# Altium schematic sheet USB_Power.SchDoc
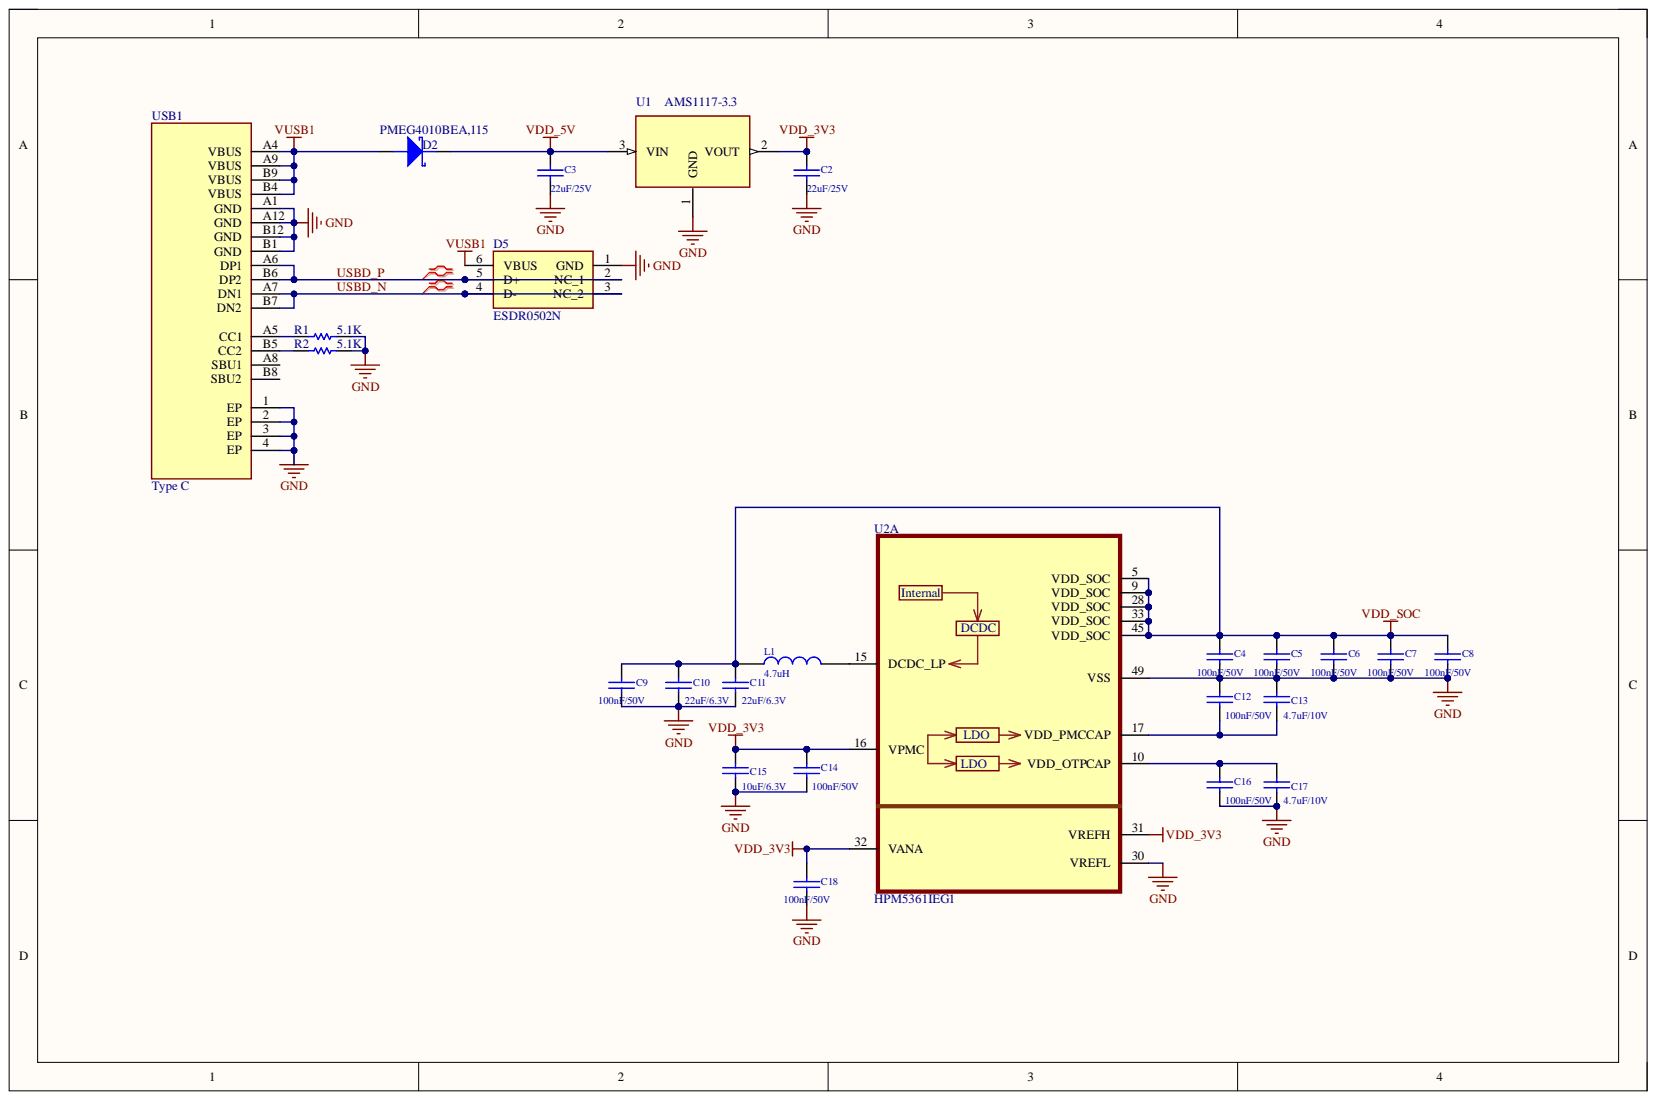
[diagram p0: USB_Power.SchDoc]

## Parts
C2  22uF/25V  [C0805]
C3  22uF/25V  [C0805]
C4  100nF/50V  [C0402]
C5  100nF/50V  [C0402]
C6  100nF/50V  [C0402]
C7  100nF/50V  [C0402]
C8  100nF/50V  [C0402]
C9  100nF/50V  [C0402]
C10  22uF/6.3V  [C0402]
C11  22uF/6.3V  [C0402]
C12  100nF/50V  [C0402]
C13  4.7uF/10V  [C0402]
C14  100nF/50V  [C0402]
C15  10uF/6.3V  [C0402]
C16  100nF/50V  [C0402]
C17  4.7uF/10V  [C0402]
C18  100nF/50V  [C0402]
D2  PMEG4010BEA,115  [SOD-323]
D5  ESDR0502N  [UDFN-6(1x1.2)]
L1  4.7uH  [R0805]
R1  5.1K  [R0402]
R2  5.1K  [R0402]
U1  AMS1117-3.3  [SOT-89]
U2  HPM5361IEG1  [QFN48 6*6]  (part A)
USB1  Type C  [TYPE-C-16]

## Nets
GND: C2.2 C3.2 C4.2 C5.2 C6.2 C7.2 C8.2 C9.2 C10.2 C11.2 C12.1 C13.1 C14.2 C15.2 C16.2 C17.2 C18.2 D5.1(GND) R1.1 R2.1 U1.1 U2.30(VREFL) U2.49(VSS) USB1.1(EP) USB1.2(EP) USB1.3(EP) USB1.4(EP) USB1.A1(GND) USB1.A12(GND) USB1.B1(GND) USB1.B12(GND)
VDD_3V3: C2.1 C14.1 C15.1 C18.1 U1.2 U2.16(VPMC) U2.31(VREFH) U2.32(VANA)
VDD_5V: C3.1 D2.K U1.3
VDD_SOC: C4.1 C5.1 C6.1 C7.1 C8.1 C9.1 C10.1 C11.1 L1.1 U2.5(VDD_SOC) U2.9(VDD_SOC) U2.28(VDD_SOC) U2.33(VDD_SOC) U2.45(VDD_SOC)
VUSB1: D2.A D5.6(VBUS) USB1.A4(VBUS) USB1.A9(VBUS) USB1.B4(VBUS) USB1.B9(VBUS)
USBD_N: D5.3(NC_2) D5.4(D-) USB1.A7(DN1) USB1.B7(DN2)
USBD_P: D5.2(NC_1) D5.5(D+) USB1.A6(DP1) USB1.B6(DP2)
NetC12_2: C12.2 C13.2 U2.17(VDD_PMCCAP)
NetC16_1: C16.1 C17.1 U2.10(VDD_OTPCAP)
NetL1_2: L1.2 U2.15(DCDC_LP)
NetR1_2: R1.2 USB1.A5(CC1)
NetR2_2: R2.2 USB1.B5(CC2)

Not connected: USB1 A8(SBU1) B8(SBU2)

## Library data of the parts
C2 C3 = Cap: Capacitor; Manufacturer: SAMSUNG; JCL-Code: C45783; Name: Capacitance; PreferredModel: CL21A226MAQNNNE; WeldingType: SMD; Component Kind: Standard; Library Name: My_Integrated_Library.SchLib
C4-C9 C12 C14 C16 C18 = Cap: Capacitor; Manufacturer: SAMSUNG(三星); JCL-Code: C307331; Name: Capacitance; PreferredModel: CL05B104KB54PNC; WeldingType: SMD; Component Kind: Standard; Library Name: My_Integrated_Library.SchLib
C10 C11 = Cap: Capacitor; Manufacturer: Murata; JCL-Code: C415703; Name: Capacitance; PreferredModel: GRM155R60J226ME11D; WeldingType: SMD; Component Kind: Standard; Library Name: My_Integrated_Library.SchLib
C13 C17 = Cap: Capacitor; Manufacturer: SAMSUNG; JCL-Code: C368809; Name: Capacitance; PreferredModel: CL05A475KP5NRNC; WeldingType: SMD; Component Kind: Standard; Library Name: My_Integrated_Library.SchLib
C15 = Cap: Capacitor; Manufacturer: SAMSUNG; JCL-Code: C15525; Name: Capacitance; PreferredModel: CL05A106MQ5NUNC; WeldingType: SMD; Component Kind: Standard; Library Name: My_Integrated_Library.SchLib
D2 = Schottky Diode: Manufacturer: Nexperia; JCL-Code: C36975; WeldingType: SMD; Component Kind: Standard; Description: Schottky Diode; Library Name: My_Integrated_Library.SchLib; Name: Schottky Diode; PreferredModel: PMEG4010BEA,115
D5 = ESDR0502N: Ultra Low Capacitance ESD Protection Array; Manufacturer: onsemi(安森美); JCL-Code: C94372; Name: TVS Diode Array; PreferredModel: ESDR0502NMUTAG; WeldingType: SMD; Component Kind: Standard; Library Name: My_Integrated_Library.SchLib
L1 = Inductors: Manufacturer: muRata; JCL-Code: C397305; PreferredModel: LQM21PN4R7NGRD; WeldingType: SMD; Component Kind: Standard; Description: Inductors; Library Name: My_Integrated_Library.SchLib; Name: Inductors
R1 R2 = Res: Resistance; Manufacturer: UNI-ROYAL(厚声); JCL-Code: C25905; PreferredModel: 0402WGF5101TCE; WeldingType: SMD; Component Kind: Standard; Library Name: My_Integrated_Library.SchLib; Name: Resistance
U1 = AMS1117-3.3 SOT-89: LDP; Manufacturer: AMS; JCL-Code: C347256; Name: LDO; WeldingType: SMD; Component Kind: Standard; Library Name: My_Integrated_Library.SchLib; PreferredModel: AMS1117-3.3
U2 = HPM5361IEG1: 32 bit RISC-V MCU; Manufacturer: HPMICRO Semiconductor; WeldingType: SMD; Component Kind: Standard; Name: 32 bit RISC-V MCU; PreferredModel: HPM5361IEG1; Library Name: HPM5301_DAPLINK.SCHLIB
USB1 = Type C: USB Type C; WeldingType: SMD; Component Kind: Standard; Library Name: My_Integrated_Library.SchLib; Name: USB Type C
